# Altium schematic sheet SDEC945-xB_Touchscreen.SchDoc(SDEC945-xB_Touchscreen)
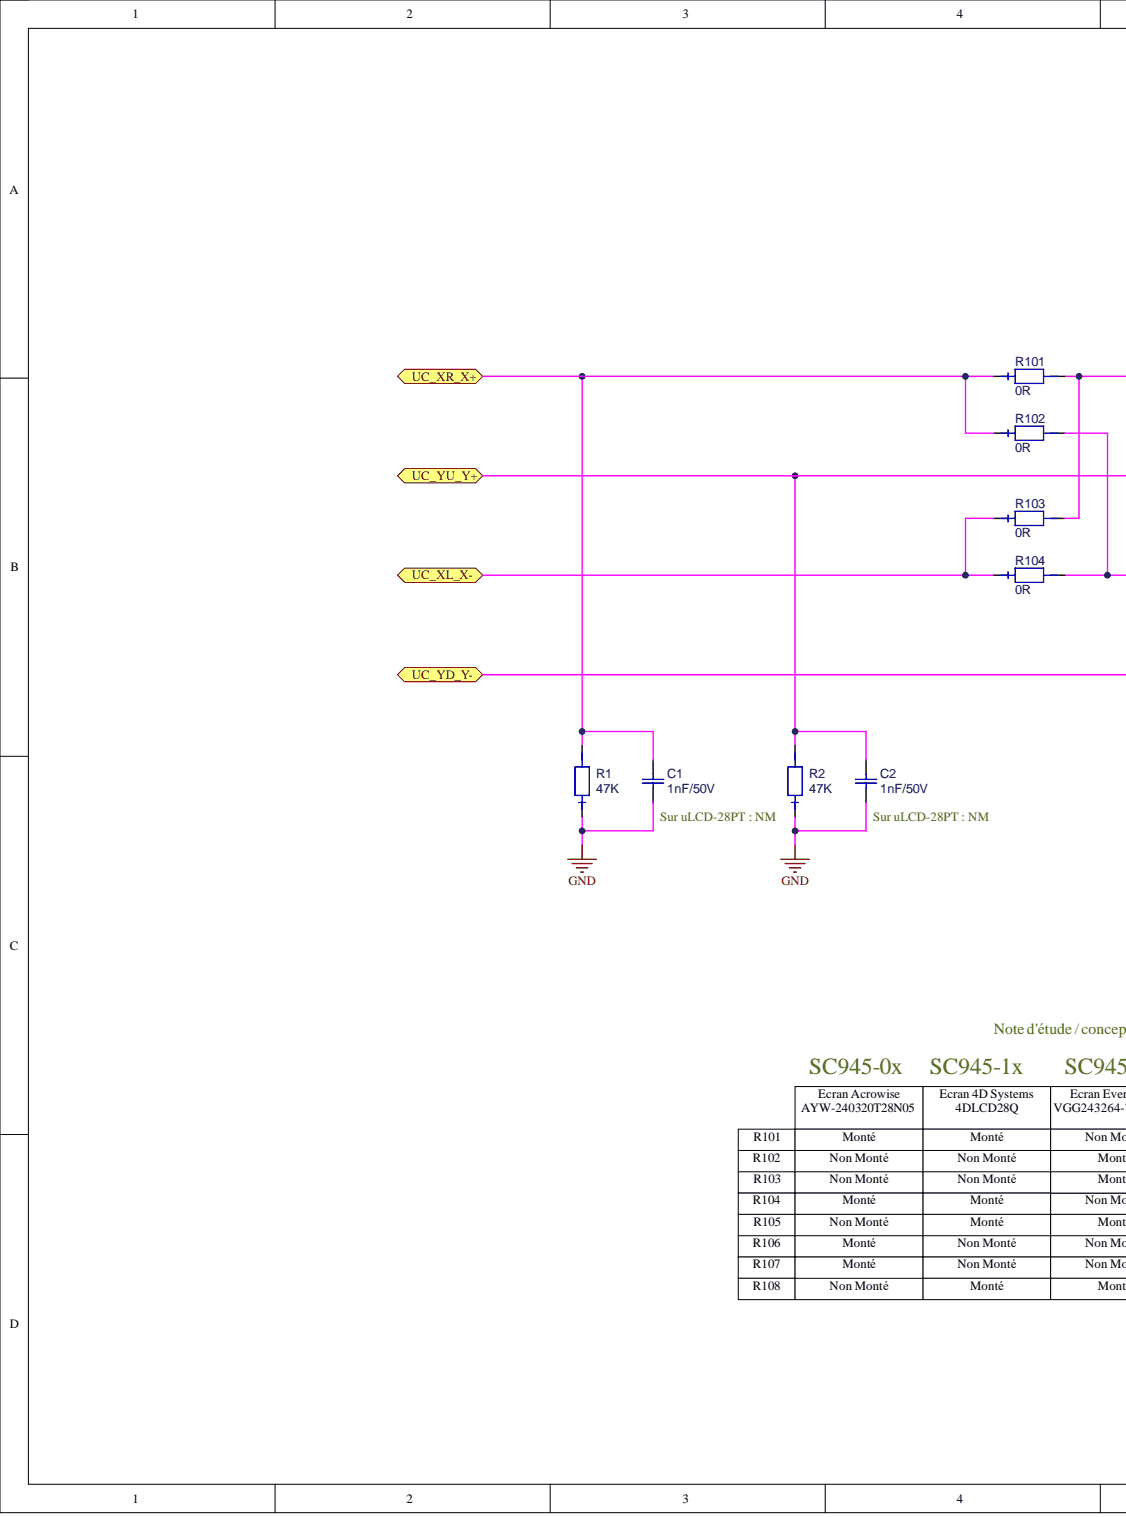
[diagram p0: SDEC945-xB_Touchscreen.SchDoc, left]
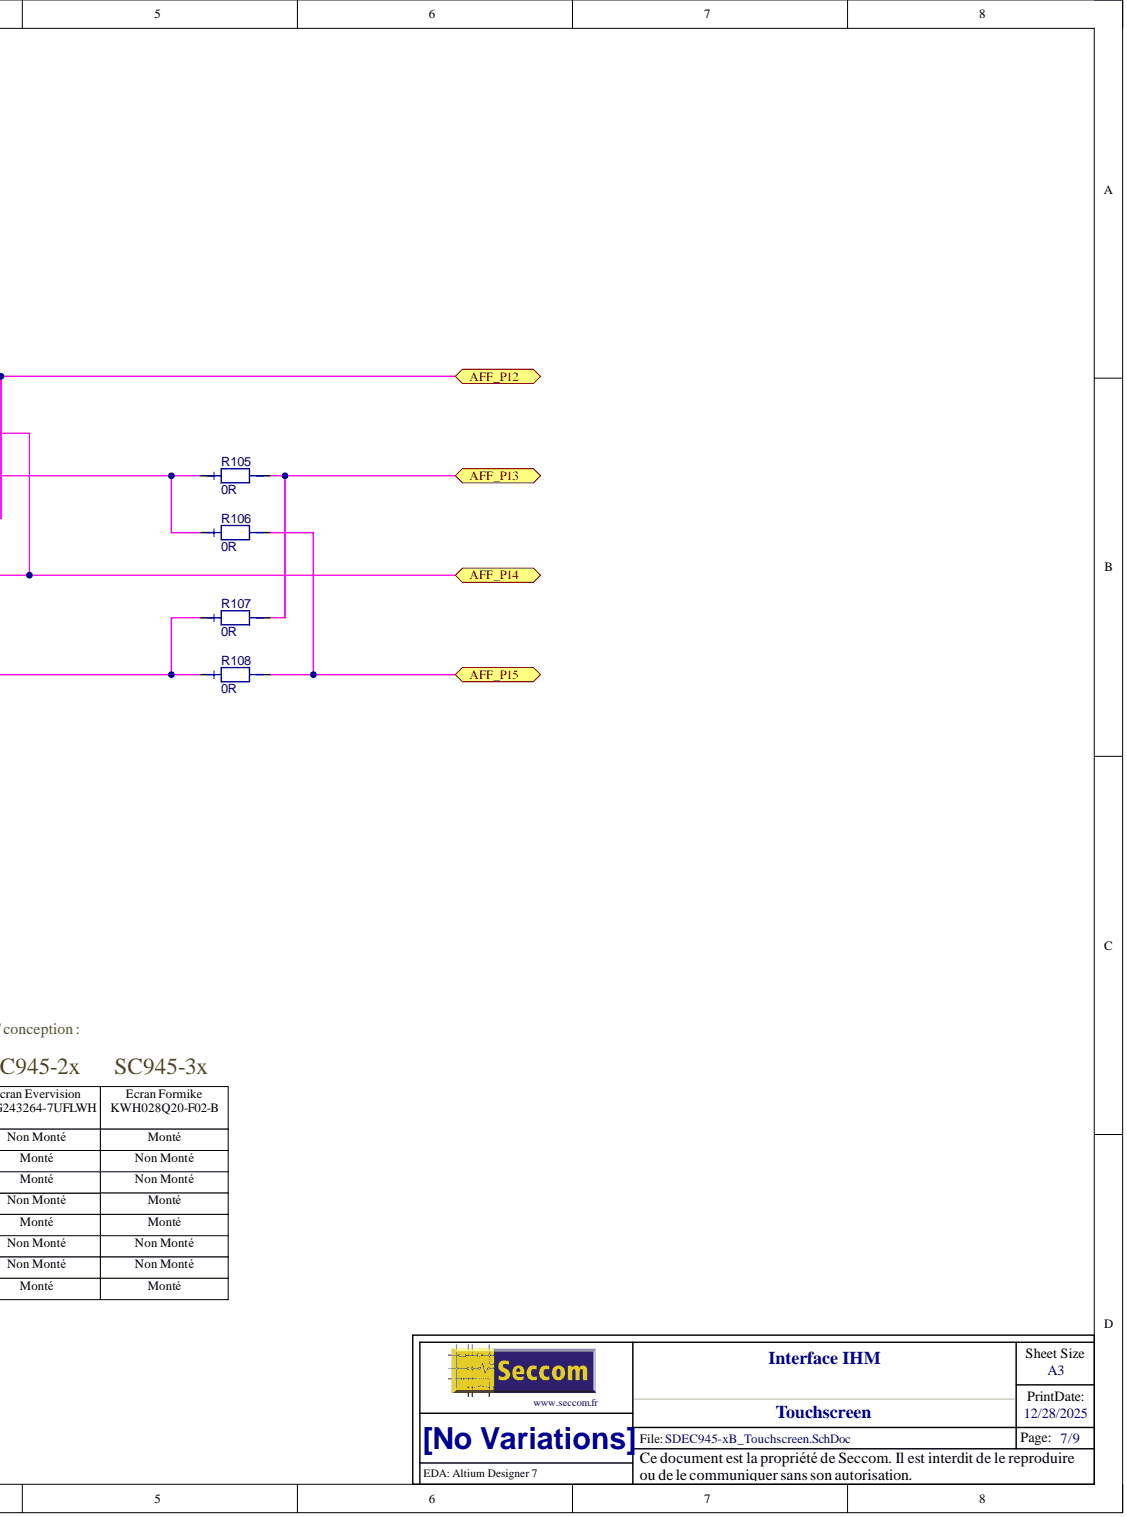
[diagram p1: SDEC945-xB_Touchscreen.SchDoc, right]

## Parts
C1  value 1nF/50V  (hidden comment: C_0603_NPO_5%_1N-50V)
C2  value 1nF/50V  (hidden comment: C_0603_NPO_5%_1N-50V)
R1  value 47K  (hidden comment: RES_0603_1%_100PPM_47K)
R2  value 47K  (hidden comment: RES_0603_1%_100PPM_47K)
R101  value 0R  (hidden comment: RES_0603_1%_100PPM_0R)
R102  value 0R  (hidden comment: RES_0603_1%_100PPM_0R)
R103  value 0R  (hidden comment: RES_0603_1%_100PPM_0R)
R104  value 0R  (hidden comment: RES_0603_1%_100PPM_0R)
R105  value 0R  (hidden comment: RES_0603_1%_100PPM_0R)
R106  value 0R  (hidden comment: RES_0603_1%_100PPM_0R)
R107  value 0R  (hidden comment: RES_0603_1%_100PPM_0R)
R108  value 0R  (hidden comment: RES_0603_1%_100PPM_0R)

## Ports
AFF_P12, AFF_P13, AFF_P14, AFF_P15, UC_XL_X-, UC_XR_X+, UC_YD_Y-, UC_YU_Y+

## Nets
GND: C1.1 C2.1 R1.1 R2.1
AFF_P12: R101.2 R103.2 ; port AFF_P12
AFF_P13: R105.2 R107.2 ; port AFF_P13
AFF_P14: R102.2 R104.2 ; port AFF_P14
AFF_P15: R106.2 R108.2 ; port AFF_P15
UC_XL_X-: R103.1 R104.1 ; port UC_XL_X-
UC_XR_X+: C1.2 R1.2 R101.1 R102.1 ; port UC_XR_X+
UC_YD_Y-: R107.1 R108.1 ; port UC_YD_Y-
UC_YU_Y+: C2.2 R2.2 R105.1 R106.1 ; port UC_YU_Y+

## Library data of the parts
C1 C2 = C_0603_NPO_5%_1N-50V: Condensateur céramique, NPO, 5%, CMS 0603; Boitier: 0603; Coeff: 1; Creation: LJ; Fabricant: AVX; Ref Fab: 06035A102JAT2A; Reference: 001809/00; Remarque: {Remarque}; Component Kind: Standard; ComponentLink1Description: Datasheet; ComponentLink2Description: Datasheet Boitier; Supplier 1: Mouser; Supplier Part Number 1: 581-06035A102J; ComponentLink1URL: o:\_Documentation\Datasheets\BC_Components\Condo_CMS_NPO-X7R-Y5V.pdf; ComponentLink2URL: o:\_Documentation\Datasheets\_BOITIERS\PASSIF_CMS\; Library Name: CAPACITIFS_CMS.SchLib
R1 R2 = RES_0603_1%_100PPM_47K: Résistance, 0.100W, 1%, 100ppm, 75V, 47K; Boitier: 0603; Coeff: 1; Creation: JPL (05/07/12); Fabricant: Vishay; Ref Fab: CRCW060347K0FKEA; Remarque: {Remarque}; Component Kind: Standard; ComponentLink1Description: Datasheet; ComponentLink2Description: Datasheet Boitier; Library Name: SC94x.SchLib; Supplier 1: Farnell; Supplier 2: RadioSpares; Supplier Part Number 1: 1469811; Supplier Part Number 2: 6790425; ComponentLink1URL: O:\_Documentation\Datasheets\Vishay\Resistances_D-CRCWe3.pdf; ComponentLink2URL: o:\_Documentation\Datasheets\_BOITIERS\PASSIF_CMS\
R101-R108 = RES_0603_1%_100PPM_0R: Résistance, 0.100W, 1%, 100ppm, 75V, 0R; Boitier: 0603; Coeff: 1; Creation: FB (04/07/12); Fabricant: Vishay; Ref Fab: CRCW06030000Z0EA; Remarque: {Remarque}; Component Kind: Standard; ComponentLink1Description: Datasheet; ComponentLink2Description: Datasheet Boitier; Library Name: SC94x.SchLib; Supplier 1: Farnell; Supplier Part Number 1: 1469739; ComponentLink1URL: O:\_Documentation\Datasheets\Vishay\Resistances_D-CRCWe3.pdf; ComponentLink2URL: o:\_Documentation\Datasheets\_BOITIERS\PASSIF_CMS\
